# Altium schematic sheet PowerDriver.SchDoc(PowerDriver)
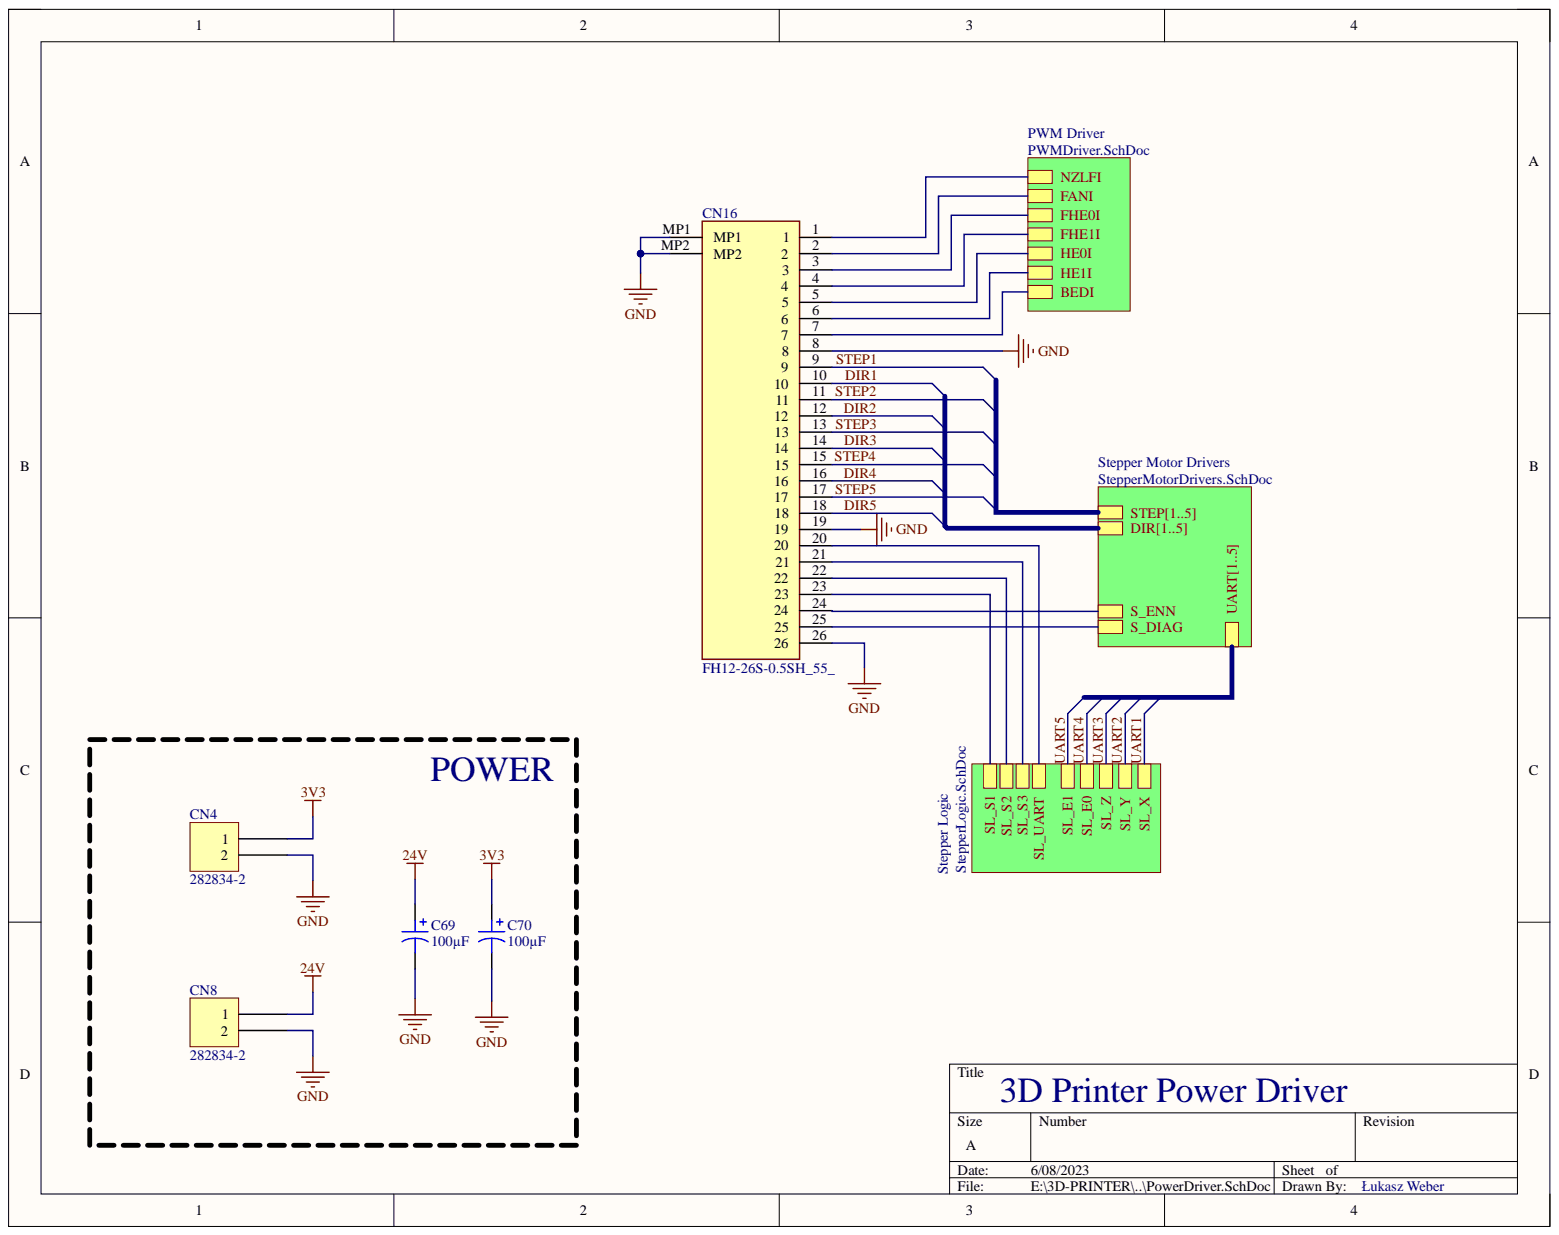
[diagram p0: PowerDriver.SchDoc]

## Parts
C69  100µF  [CAP ALSMD POLY 5.3MM H6.0MM]
C70  100µF  [CAP ALSMD POLY 5.3MM H6.0MM]
CN4  282834-2  [TE 282834-2]
CN8  282834-2  [TE 282834-2]
CN16  FH12-26S-0.5SH_55_  [FH1226S05SH55]

## Nets
3V3: C70.1 CN4.1
24V: C69.1 CN8.1
GND: C69.2 C70.2 CN4.2 CN8.2 CN16.8 CN16.19 CN16.26 CN16.MP1 CN16.MP2
DIR1: CN16.10  [also labelled DIR]
DIR2: CN16.12  [also labelled DIR]
DIR3: CN16.14  [also labelled DIR]
DIR4: CN16.16  [also labelled DIR]
DIR5: CN16.18  [also labelled DIR]
STEP1: CN16.9  [also labelled STEP]
STEP2: CN16.11  [also labelled STEP]
STEP3: CN16.13  [also labelled STEP]
STEP4: CN16.15  [also labelled STEP]
STEP5: CN16.17  [also labelled STEP]
NetCN16_1: CN16.1
NetCN16_2: CN16.2
NetCN16_3: CN16.3
NetCN16_4: CN16.4
NetCN16_5: CN16.5
NetCN16_6: CN16.6
NetCN16_7: CN16.7
NetCN16_20: CN16.20
NetCN16_21: CN16.21
NetCN16_22: CN16.22
NetCN16_23: CN16.23
NetCN16_24: CN16.24
NetCN16_25: CN16.25
UART1:  [also labelled UART]
UART2:  [also labelled UART]
UART3:  [also labelled UART]
UART4:  [also labelled UART]
UART5:  [also labelled UART]

## Library data of the parts
C69 C70 = 6SVPC100M: CAP ALUM POLY 100UF 20% 6.3V SMD; manufacturer: Panasonic Electronic Components; Database Table Name: Capacitors - Aluminum - Polymer SMT; ESR (Equivalent Series Resistance): 30mOhm; Lifetime @ Temp.: 2000 Hrs @ 105°C; Ripple Current @ High Frequency: 2.15A @ 100kHz; Ripple Current @ Low Frequency: 107.5mA @ 120Hz; Surface Mount Land Size: 0.209" L x 0.209" W (5.30mm x 5.30mm); Type: Polymer; Applications: General Purpose; Capacitance: 100µF; Component Kind: Standard; componentlink1description: Datasheet; componentlink1url: https://industrial.panasonic.com/cdbs/www-data/pdf/AAB8000/AAB8000C256.pdf; componentlink2description: DigiKey Link; componentlink2url: http://digikey.com/product-detail/en/panasonic-electronic-components/6SVPC100M/P16606CT-ND/4204442; Device Package: Radial, Can - SMD; Height - Seated (Max): 0.236" (6.00mm); lastupdated: 9/2/2020 1:22:07 AM; Library Name: Celestial Altium Library - altium_library.DbLib; Lifecycle Status: Active; Minimum Order: 1; Mounting Type: Surface Mount; Operating Temperature: -55°C ~ 105°C; Package / Case: Radial, Can - SMD; packaging: TapeAndReel; Part Number: 6SVPC100M; Part Status: Active; partid: 1943; price: 0.51; series: OS-CON™, SVPC; Size / Dimension: 0.197" Dia (5.00mm); Supplier 1: DigiKey; Supplier Part Number 1: P16606CT-ND; Tolerance: ±20%; value: 100µF; Voltage - Rated: 6.3V
CN4 CN8 = 282834-2: TERM BLK 2P SIDE ENT 2.54MM PCB; Manufacturer: TE Connectivity AMP Connectors; Current: 10A; Database Table Name: Connectors - Terminal Blocks - Wire to Board; Mating Orientation: Horizontal with Board; Number of Levels: 1; Pitch: 0.100" (2.54mm); Positions Per Level: 2; Voltage: 150V; Wire Gauge: 16-30 AWG; Wire Termination: Screw - Rising Cage Clamp; Color: Green; Component Kind: Standard; ComponentLink1Description: Datasheet; ComponentLink1URL: https://www.te.com/commerce/DocumentDelivery/DDEController?Action=srchrtrv&DocNm=282834&DocType=Customer+Drawing&DocLang=English; ComponentLink2Description: DigiKey Link; ComponentLink2URL: http://digikey.com/product-detail/en/te-connectivity-amp-connectors/282834-2/A98333-ND/1150135; LastUpdated: 1/15/2020 4:15:38 AM; Library Name: Celestial Altium Library - altium_library.DbLib; Lifecycle Status: Active; Minimum Order: 1; Mounting Type: Through Hole; Packaging: Bulk; Part Number: 282834-2; Part Status: Active; Price: 0.88; Series: Buchanan; Supplier 1: DigiKey; Supplier Part Number 1: A98333-ND
CN16 = FH12-26S-0.5SH_55_: Connector; Manufacturer_Name: Hirose; Manufacturer_Part_Number: FH12-26S-0.5SH(55); Arrow Part Number: FH12-26S-0.5SH(55); Arrow Price/Stock: https://www.arrow.com/en/products/fh12-26s-0.5sh-55/hirose-electric; Component Kind: Standard; Datasheet Link: https://datasheet.datasheetarchive.com/originals/distributors/SFDatasheet-1/sf-00023459.pdf; Height: 2.2mm; Library Name: SamacSys.SchLib; Mouser Part Number: 798-FH12-26S-0.5SH55; Mouser Price/Stock: https://www.mouser.co.uk/ProductDetail/Hirose-Connector/FH12-26S-0.5SH55?qs=Ux3WWAnHpjC%2FipJJsmJDaQ%3D%3D
